# Altium schematic sheet ArcNano_Schematic_POWER.SchDoc
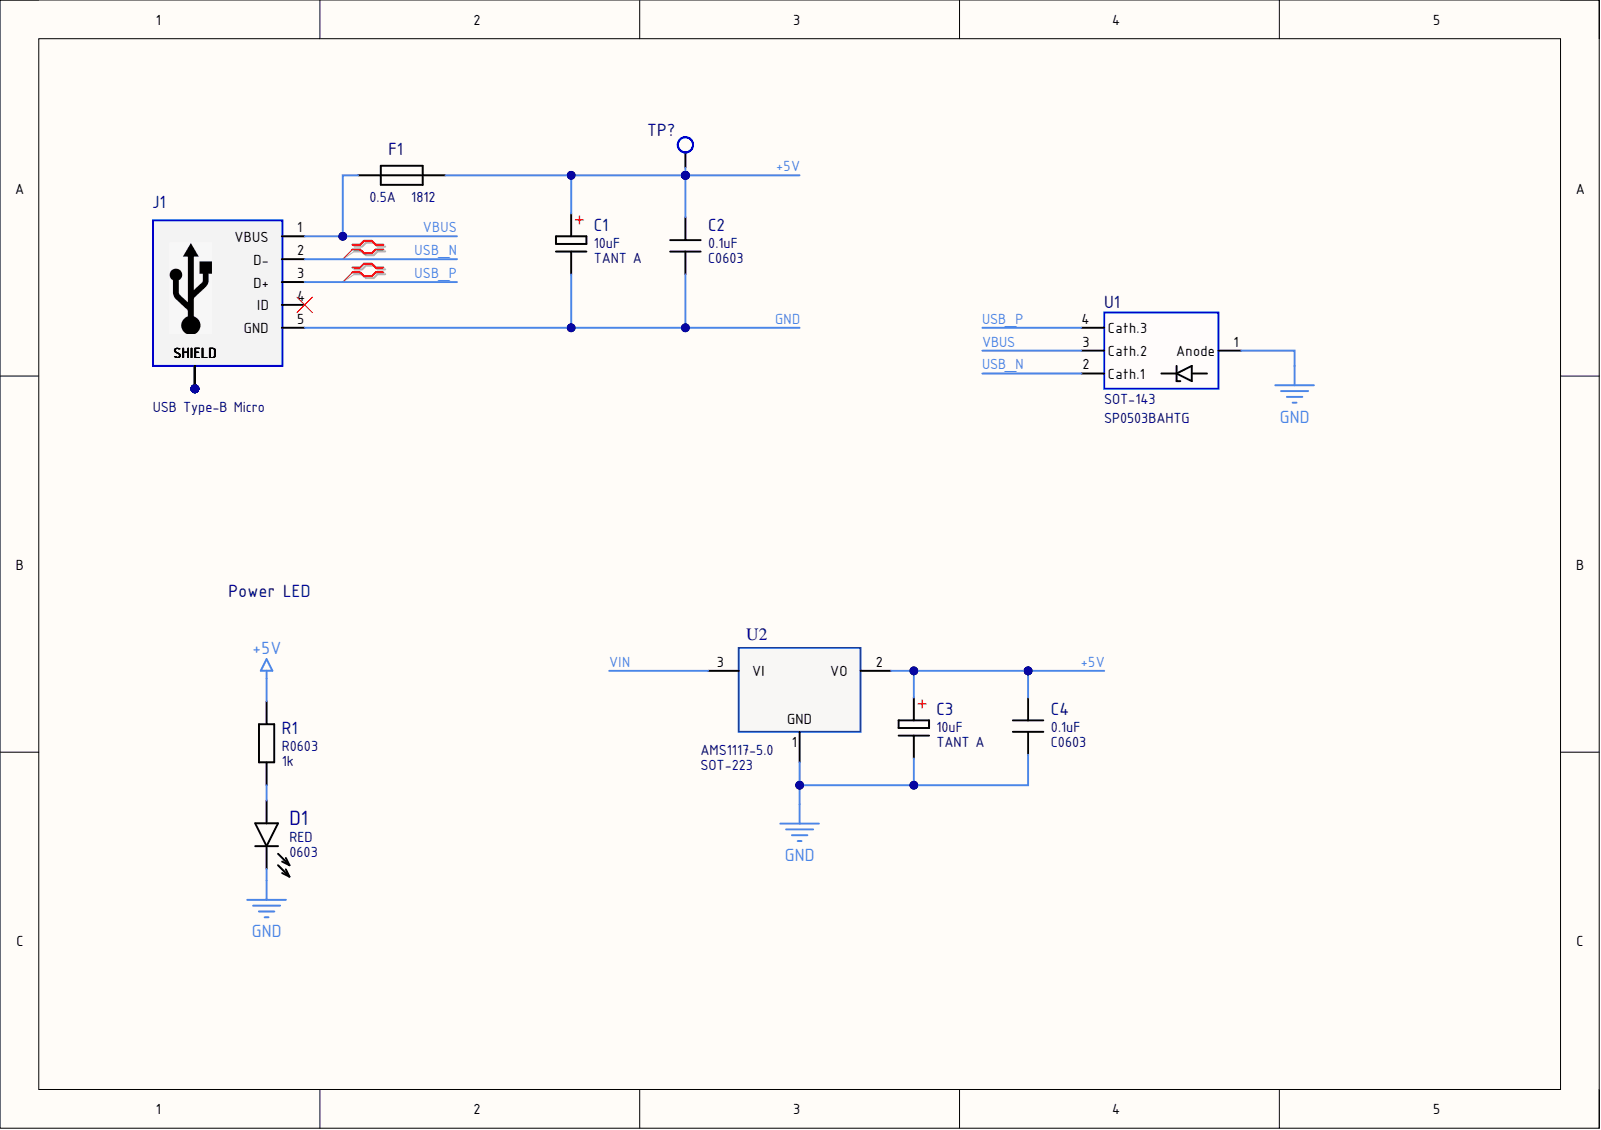
[diagram p0: ArcNano_Schematic_POWER.SchDoc]

## Parts
C1  value 10uF  [TANT A]
C2  value 0.1uF  [C0603]
C3  value 10uF  [TANT A]
C4  value 0.1uF  [C0603]
D1  value RED  Case: 0603  [D0603_LED_RED]
F1  value 0.5A  Case: 1812  [MF-MSMF050]
J1  USB Type-B Micro  [USB MOLEX 1050170001]
R1  value 1k  [R0603]
U1  SP0503BAHTG  Case: SOT-143  [SOT143-4]
U2  AMS1117-5.0  [SOT-223]

## Nets
+5V: C1.1 C2.2 C3.1 C4.2 F1.2 R1.1 U2.2
GND: C1.2 C2.1 C3.2 C4.1 D1.2 J1.5(GND) U1.1(Anode) U2.1
USB_N: J1.2(D-) U1.2(Cath.1)
USB_P: J1.3(D+) U1.4(Cath.3)
VBUS: F1.1 J1.1(VBUS) U1.3(Cath.2)
VIN: U2.3
NetD1_1: D1.1 R1.2
NetJ1_SH1: J1.SH1 J1.SH2 J1.SH3 J1.SH4 J1.SH5 J1.SH6 J1.SH7 J1.SH8

Not connected: J1 4(ID)

## Library data of the parts
C1 C3 = Capacitor_POL: Manufacturer: AVX; MFR.Part #: TAJA106K016RNJ; Case: TANT A; Component Kind: Standard; HelpURL: https://lcsc.com/product-detail/Tantalum-Capacitors_AVX-TAJA106K016RNJ_C7171.html; JLCPCB Part #: C7171; Library Name: ADLibrary_Schematics.SchLib; Model: TAJA106K016RNJ
C2 C4 = Capacitor: Manufacturer: Murata Electronics; MFR.Part #: GRM188R71H104KA93D; Case: C0603; Component Kind: Standard; HelpURL: https://lcsc.com/product-detail/Multilayer-Ceramic-Capacitors-MLCC-SMD-SMT_Murata-Electronics-GRM188R71H104KA93D_C77055.html; JLCPCB Part #: C77055; Library Name: ADLibrary_Schematics.SchLib; Model: GRM188R71H104KA93D
D1 = LED: Manufacturer: Everlight Elec; MFR.Part #: 19-217/R6C-AL1M2VY/3T; Component Kind: Standard; HelpURL: https://lcsc.com/product-detail/Light-Emitting-Diodes-LED_Everlight-Elec-19-217-R6C-AL1M2VY-3T_C72044.html; JLCPCB Part #: C72044; Library Name: ADLibrary_Schematics.SchLib; Model: 19-217/R6C-AL1M2VY/3T
F1 = Fuse: Manufacturer: Bourns; MFR.Part #: MF-MSMF050-2; Component Kind: Standard; HelpURL: https://lcsc.com/product-detail/Resettable-Fuses_BOURNS-MF-MSMF050-2_C17313.html; JLCPCB Part #: C17313; Library Name: ADLibrary_Schematics.SchLib; Model: MF-MSMF050
J1 = USB Type-B Micro: USB - micro B USB 2.0 Receptacle Connector 5 Position Surface Mount, Right Angle; Through Hole; Manufacturer: Molex; MFR.Part #: 1050170001; Case: USB Type-B Micro; Component Kind: Standard; HelpURL: https://lcsc.com/product-detail/USB-Connectors_MOLEX-1050170001_C136000.html; JLCPCB Part #: C136000; Library Name: ADLibrary_Library.IntLib; Model: USB Type-B Micro
R1 = Resistor: Manufacturer: YAGEO; MFR.Part #: RC0603JR-071KL; Case: R0603; Component Kind: Standard; HelpURL: https://lcsc.com/product-detail/Chip-Resistor-Surface-Mount_YAGEO-RC0603JR-071KL_C14676.html; JLCPCB Part #: C14676; Library Name: ADLibrary_Schematics.SchLib; Model: RC0603JR-071KL
U1 = SP0503BAHTG: TVS DIODE 5.5V 8.5V; Manufacturer: Littelfuse Inc.; Component Kind: Standard; HelpURL: https://lcsc.com/product-detail/TVS_Littelfuse-SP0503BAHTG_C7074.html; JLCPCB Part #: C7074; Library Name: ADLibrary_Schematics.SchLib; MFR.Part #: SP0503BAHTG; Model: SP0503BAHTG
U2 = AMS1117-5.0: Manufacturer: Advanced Monolithic Systems; Case: SOT-223; Component Kind: Standard; HelpURL: https://lcsc.com/product-detail/Linear-Voltage-Regulators-LDO_Advanced-Monolithic-Systems-AMS1117-5-0_C6187.html; JLCPCB Part #: C6187; Library Name: ADLibrary_Schematics.SchLib; MFR.Part #: AMS1117-5.0; Model: AMS1117-5.0
